# Altium schematic sheet pyWiFi-ESP32-S3.SchDoc(pyWiFi-ESP32-S3)
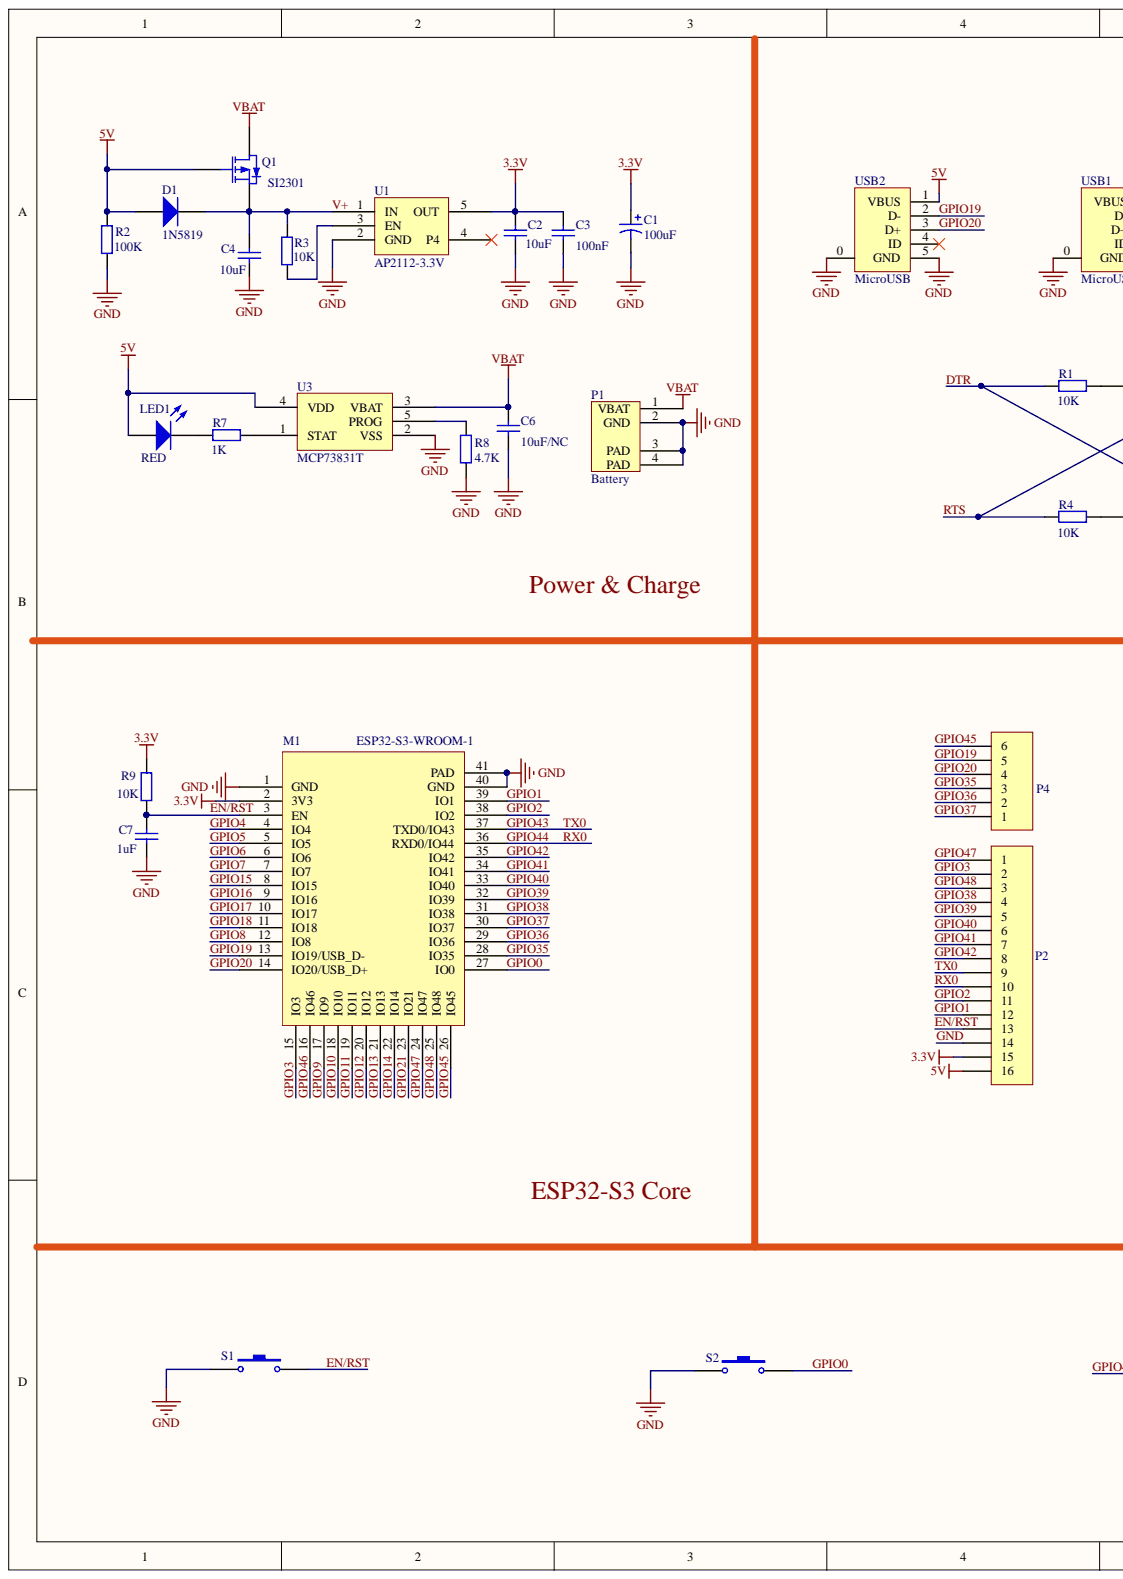
[diagram p0: pyWiFi-ESP32-S3.SchDoc, left]
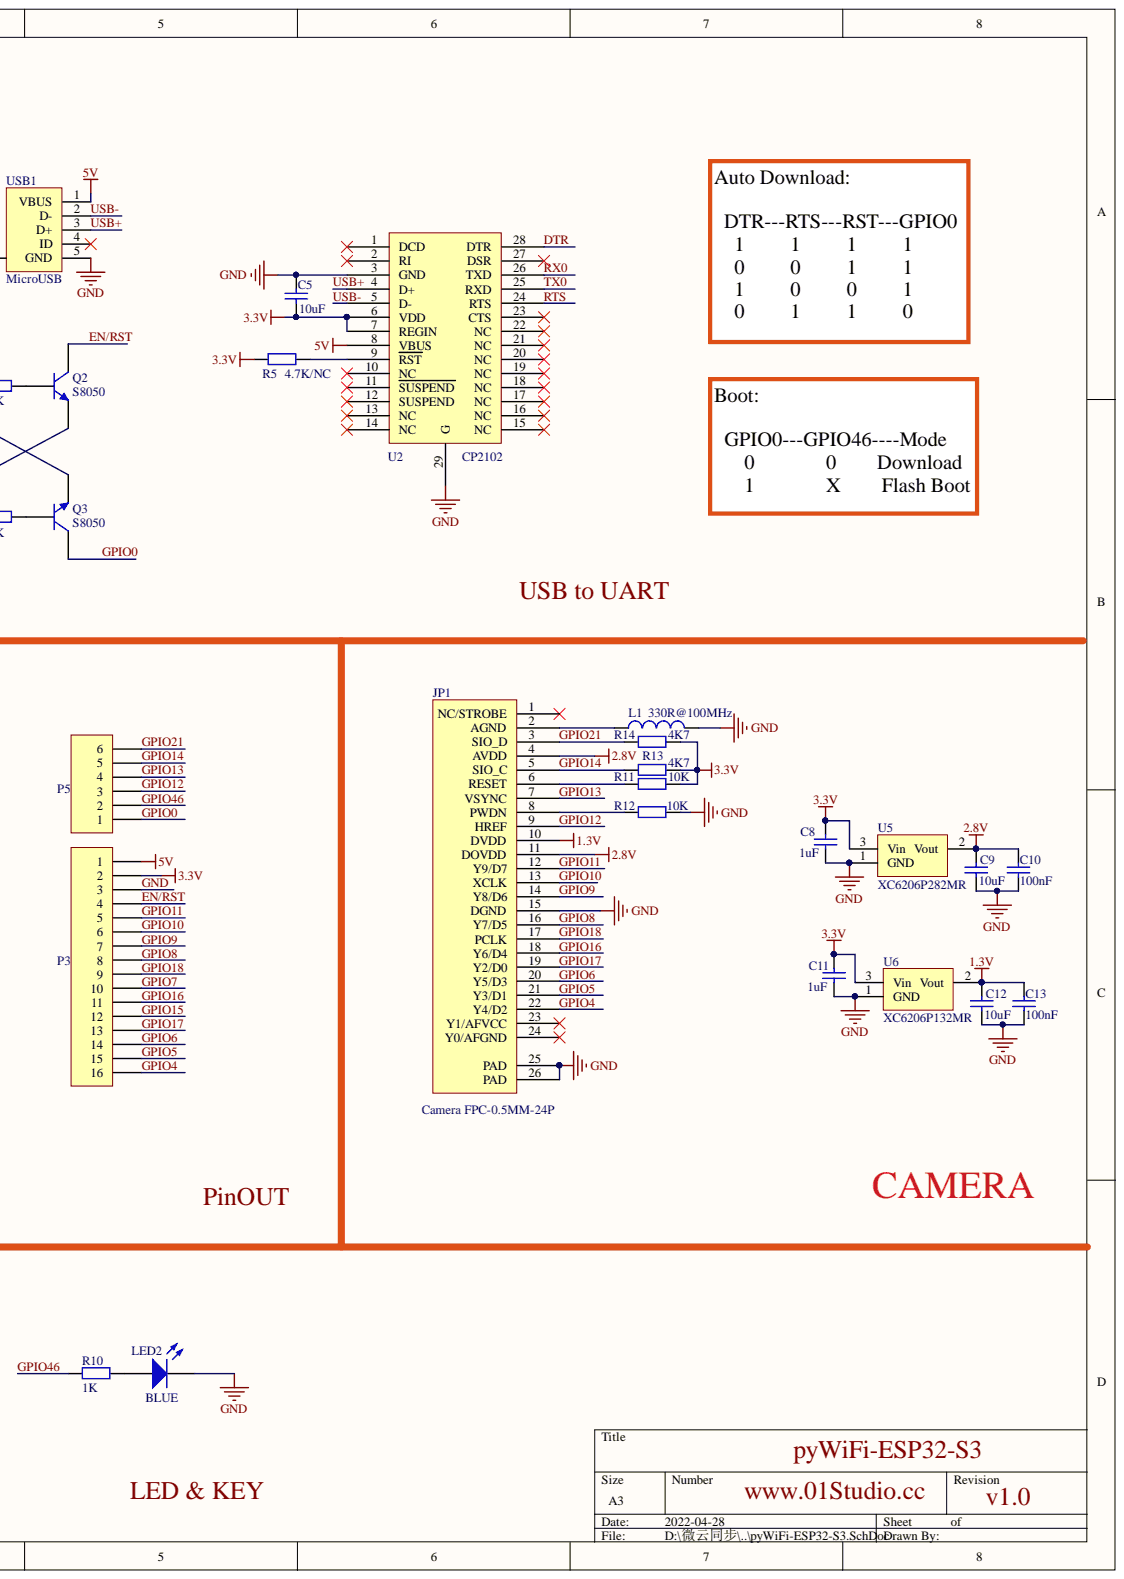
[diagram p1: pyWiFi-ESP32-S3.SchDoc, right]

## Parts
C1  100uF  [B型钽电容]
C2  10uF  [C0402]
C3  100nF  [C0402]
C4  10uF  [C0402]
C5  10uF  [C0402]
C6  10uF/NC  [C0402]
C7  1uF  [C0402]
C8  1uF  [C0402]
C9  10uF  [C0402]
C10  100nF  [C0402]
C11  1uF  [C0402]
C12  10uF  [C0402]
C13  100nF  [C0402]
D1  1N5819  [SOD-323]
JP1  Camera FPC-0.5MM-24P  [FPC_24P_UP&DOWN]
L1  330R@100MHz  [L0603]
LED1  RED  [D0603]
LED2  BLUE  [D0603]
M1  ESP32-S3-WROOM-1  [ESP32-S3-WROOM-1]
P1  Battery  [XH2.54-2P/卧贴]
P2  (no value)  [1*16P/2.54]
P3  (no value)  [1*16P/2.54]
P4  (no value)  [1*6 2.54mm 排针]
P5  (no value)  [1*6 2.54mm 排针]
Q1  SI2301  [SOT-23]
Q2  S8050  [SOT-23]
Q3  S8050  [SOT-23]
R1  10K  [R0402]
R2  100K  [R0402]
R3  10K  [R0402]
R4  10K  [R0402]
R5  4.7K/NC  [R0402]
R7  1K  [R0402]
R8  4.7K  [R0402]
R9  10K  [R0402]
R10  1K  [R0402]
R11  10K  [R0402]
R12  10K  [R0402]
R13  4K7  [R0402]
R14  4K7  [R0402]
S1  (no value)  [贴片按键/3.2*4.2*2.5mm]
S2  (no value)  [贴片按键/3.2*4.2*2.5mm]
U1  AP2112-3.3V  [SOT23-5]
U2  CP2102  [QFN28 5x5_L]
U3  MCP73831T  [SOT23-5]
U5  XC6206P282MR  [SOT-23]
U6  XC6206P132MR  [SOT-23]
USB1  MicroUSB  [MicroUSB(母座前插后贴)]
USB2  MicroUSB  [MicroUSB(母座前插后贴)]

## Nets
1.3V: C12.2 C13.2 JP1.10(DVDD) U6.2
2.8V: C9.2 C10.2 JP1.4(AVDD) JP1.11(DOVDD) U5.2
3.3V: C1.1 C2.2 C3.2 C5.2 C8.1 C11.1 M1.2(3V3) P2.15 P3.2 R5.1 R9.2 R11.2 R13.2 R14.2 U1.5(OUT) U2.6(VDD) U2.7(REGIN) U5.3 U6.3
5V: D1.1 LED1.1 P2.16 P3.1 Q1.1 R2.1 U2.8(VBUS) U3.4(VDD) USB1.1(VBUS) USB2.1(VBUS)
VBAT: C6.2 P1.1(VBAT) Q1.3 U3.3(VBAT)
DTR: Q3.2 R1.1 U2.28(DTR)
EN/RST: C7.2 M1.3(EN) P2.13 P3.4 Q2.3 R9.1 S1.2
GND: C1.2 C2.1 C3.1 C4.1 C5.1 C6.1 C7.1 C8.2 C9.1 C10.1 C11.2 C12.1 C13.1 JP1.15(DGND) JP1.25(PAD) JP1.26(PAD) L1.1 LED2.2 M1.1(GND) M1.40(GND) M1.41(PAD) P1.2(GND) P1.3(PAD) P1.4(PAD) P2.14 P3.3 R2.2 R8.1 R12.2 S1.1 S2.1 U1.2(GND) U2.3(GND) U2.29(G) U3.2(VSS) U5.1 U6.1 USB1.0 USB1.5(GND) USB2.0 USB2.5(GND)
GPIO0: M1.27(IO0) P5.1 Q3.3 S2.2
GPIO1: M1.39(IO1) P2.12
GPIO2: M1.38(IO2) P2.11
GPIO3: M1.15(IO3) P2.2
GPIO4: JP1.22(Y4/D2) M1.4(IO4) P3.16
GPIO5: JP1.21(Y3/D1) M1.5(IO5) P3.15
GPIO6: JP1.20(Y5/D3) M1.6(IO6) P3.14
GPIO7: M1.7(IO7) P3.10
GPIO8: JP1.16(Y7/D5) M1.12(IO8) P3.8
GPIO9: JP1.14(Y8/D6) M1.17(IO9) P3.7
GPIO10: JP1.13(XCLK) M1.18(IO10) P3.6
GPIO11: JP1.12(Y9/D7) M1.19(IO11) P3.5
GPIO12: JP1.9(HREF) M1.20(IO12) P5.3
GPIO13: JP1.7(VSYNC) M1.21(IO13) P5.4
GPIO14: JP1.5(SIO_C) M1.22(IO14) P5.5 R13.1
GPIO15: M1.8(IO15) P3.12
GPIO16: JP1.18(Y6/D4) M1.9(IO16) P3.11
GPIO17: JP1.19(Y2/D0) M1.10(IO17) P3.13
GPIO18: JP1.17(PCLK) M1.11(IO18) P3.9
GPIO19: M1.13(IO19/USB_D-) P4.5 USB2.2(D-)
GPIO20: M1.14(IO20/USB_D+) P4.4 USB2.3(D+)
GPIO21: JP1.3(SIO_D) M1.23(IO21) P5.6 R14.1
GPIO35: M1.28(IO35) P4.3
GPIO36: M1.29(IO36) P4.2
GPIO37: M1.30(IO37) P4.1
GPIO38: M1.31(IO38) P2.4
GPIO39: M1.32(IO39) P2.5
GPIO40: M1.33(IO40) P2.6
GPIO41: M1.34(IO41) P2.7
GPIO42: M1.35(IO42) P2.8
GPIO43: M1.37(TXD0/IO43) P2.9 U2.25(RXD)
GPIO44: M1.36(RXD0/IO44) P2.10 U2.26(TXD)
GPIO45: M1.26(IO45) P4.6
GPIO46: M1.16(IO46) P5.2 R10.1
GPIO47: M1.24(IO47) P2.1
GPIO48: M1.25(IO48) P2.3
RTS: Q2.2 R4.1 U2.24(RTS)
USB+: U2.4(D+) USB1.3(D+)
USB-: U2.5(D-) USB1.2(D-)
V+: C4.2 D1.2 Q1.2 R3.1 U1.1(IN)
NetJP1_2: JP1.2(AGND) L1.2
NetJP1_6: JP1.6(RESET) R11.1
NetJP1_8: JP1.8(PWDN) R12.1
NetLED1_2: LED1.2 R7.1
NetLED2_1: LED2.1 R10.2
NetQ2_1: Q2.1 R1.2
NetQ3_1: Q3.1 R4.2
NetR3_2: R3.2 U1.3(EN)
NetR5_2: R5.2 U2.9(RST)
NetR7_2: R7.2 U3.1(STAT)
NetR8_2: R8.2 U3.5(PROG)

Not connected: JP1 1(NC/STROBE) 23(Y1/AFVCC) 24(Y0/AFGND); U1 4(P4); U2 1(DCD) 2(RI) 10(NC) 11(SUSPEND) 12(SUSPEND) 13(NC) 14(NC) 15(NC) 16(NC) 17(NC) 18(NC) 19(NC) 20(NC) 21(NC) 22(NC) 23(CTS) 27(DSR); USB1 4(ID); USB2 4(ID)

## Library data of the parts
C1 = Cap Pol1: Polarized Capacitor (Radial); Simulation: CAP; Signal Integrity: Cap Pol; Published: 8-Jun-2000; PackageReference: RB7.6-15; Library Name: Miscellaneous Devices.IntLib; LatestRevisionNote: Re-released for DXP Platform.; LatestRevisionDate: 17-Jul-2002; Component Kind: Standard; Publisher: Altium Limited
C2-C13 = Cap: Capacitor; Simulation: CAP; Signal Integrity: Cap; Published: 8-Jun-2000; PackageReference: RAD-0.3; Library Name: Miscellaneous Devices.IntLib; LatestRevisionNote: Re-released for DXP Platform.; LatestRevisionDate: 17-Jul-2002; Component Kind: Standard; Publisher: Altium Limited
D1 = Diode: Default Diode; Simulation: DIODE; Signal Integrity: Diode; Published: 8-Jun-2000; PackageReference: SMC; PackageDocument: Sep-1996; Library Name: Miscellaneous Devices.IntLib; LatestRevisionNote: Re-released for DXP Platform.; LatestRevisionDate: 17-Jul-2002; Component Kind: Standard; Code_JEDEC: DO-214-AB; Publisher: Altium Limited
JP1 = CAM_24PIN_CONNECTOR: Library Name: 01Studio.SchLib; Component Kind: Standard
L1 = Inductor: Code_IEC: 1005; Simulation: INDUCTOR; Signal Integrity: Inductor; Published: 8-Jun-2000; PackageReference: 0402-A; PackageDescription: Chip Inductor; Library Name: Miscellaneous Devices.IntLib; LatestRevisionNote: IPC-7351 Footprint Added.; LatestRevisionDate: 29-May-2009; Description: Inductor; Component Kind: Standard; Code_JEITA: 0402; Publisher: Altium Limited
LED1 LED2 = LED1: Typical RED GaAs LED; Simulation: LED1; Published: 8-Jun-2000; PackageReference: LED-1; Library Name: Miscellaneous Devices.IntLib; LatestRevisionNote: Mech Layer 1 removed.; LatestRevisionDate: 08-Jul-2005; Component Kind: Standard; Publisher: Altium Limited
P1 = 2P-BATTERY: Library Name: 01Studio.SchLib; Component Kind: Standard
P2 P3 = Header 16: Header, 16-Pin; Signal Integrity: Connector; Library Name: Miscellaneous Connectors.IntLib; LatestRevisionNote: Re-released for DXP Platform.; LatestRevisionDate: 17-Jul-2002; Component Kind: Standard; Publisher: Altium Limited
P4 P5 = Header 6: Header, 6-Pin; Signal Integrity: Connector; Library Name: Miscellaneous Connectors.IntLib; LatestRevisionNote: Re-released for DXP Platform.; LatestRevisionDate: 17-Jul-2002; Component Kind: Standard; Publisher: Altium Limited
Q1 = P-MOS: Library Name: WeBee.SCHLIB; Component Kind: Standard
R1-R5 R7-R14 = Res2: Resistor; Simulation: RESISTOR; Signal Integrity: Res; Published: 8-Jun-2000; PackageReference: AXIAL-0.4; Library Name: Miscellaneous Devices.IntLib; LatestRevisionNote: Re-released for DXP Platform.; LatestRevisionDate: 17-Jul-2002; Component Kind: Standard; Publisher: Altium Limited
S1 S2 = SW-PB: Switch; Published: 24-Mar-1999; PackageReference: SPST-2; Library Name: Miscellaneous Devices.IntLib; LatestRevisionNote: Re-released for DXP Platform.; LatestRevisionDate: 17-Jul-2002; Component Kind: Standard; Publisher: Altium Limited
U1 = AP2112: Library Name: 01Studio.SchLib; Component Kind: Standard
U5 U6 = XC6206P332MR: Library Name: WeBee.SCHLIB; Component Kind: Standard
M1 = ESP32-S3-WROOM-1: Library Name: 01Studio.SchLib; Component Kind: Standard
Q2 Q3 = S8050: Library Name: WeBee.SCHLIB; Component Kind: Standard
U2 = CP2102: Library Name: WeBee.SCHLIB; Component Kind: Standard
U3 = MCP73831T: Component Kind: Standard
USB1 USB2 = MicroUSB: Library Name: WeBee.SCHLIB; Component Kind: Standard
